# Altium schematic sheet P10_MISCELLANEOUS.SchDoc(P10_MISCELLANEOUS)
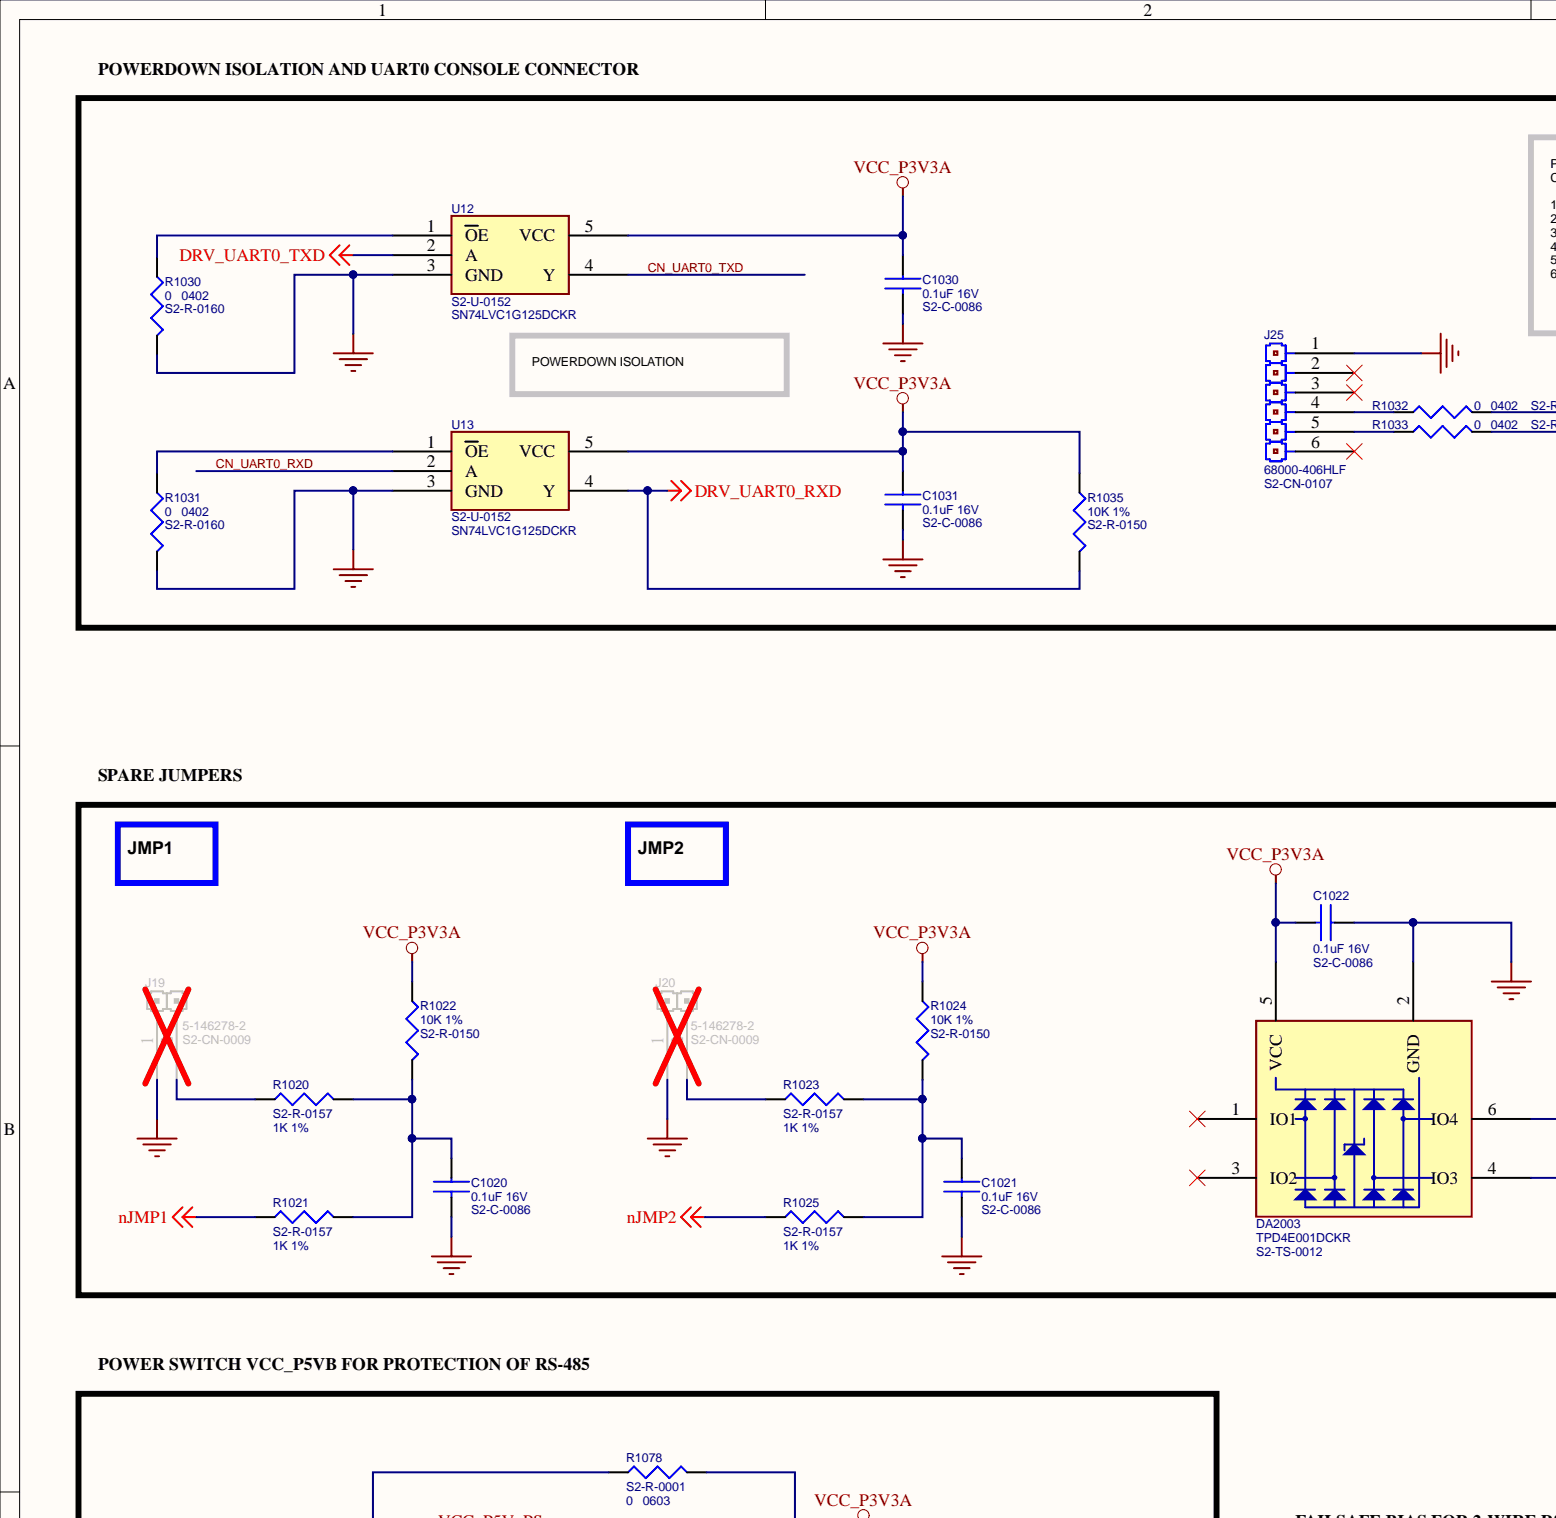
[diagram p0: P10_MISCELLANEOUS.SchDoc, top left]
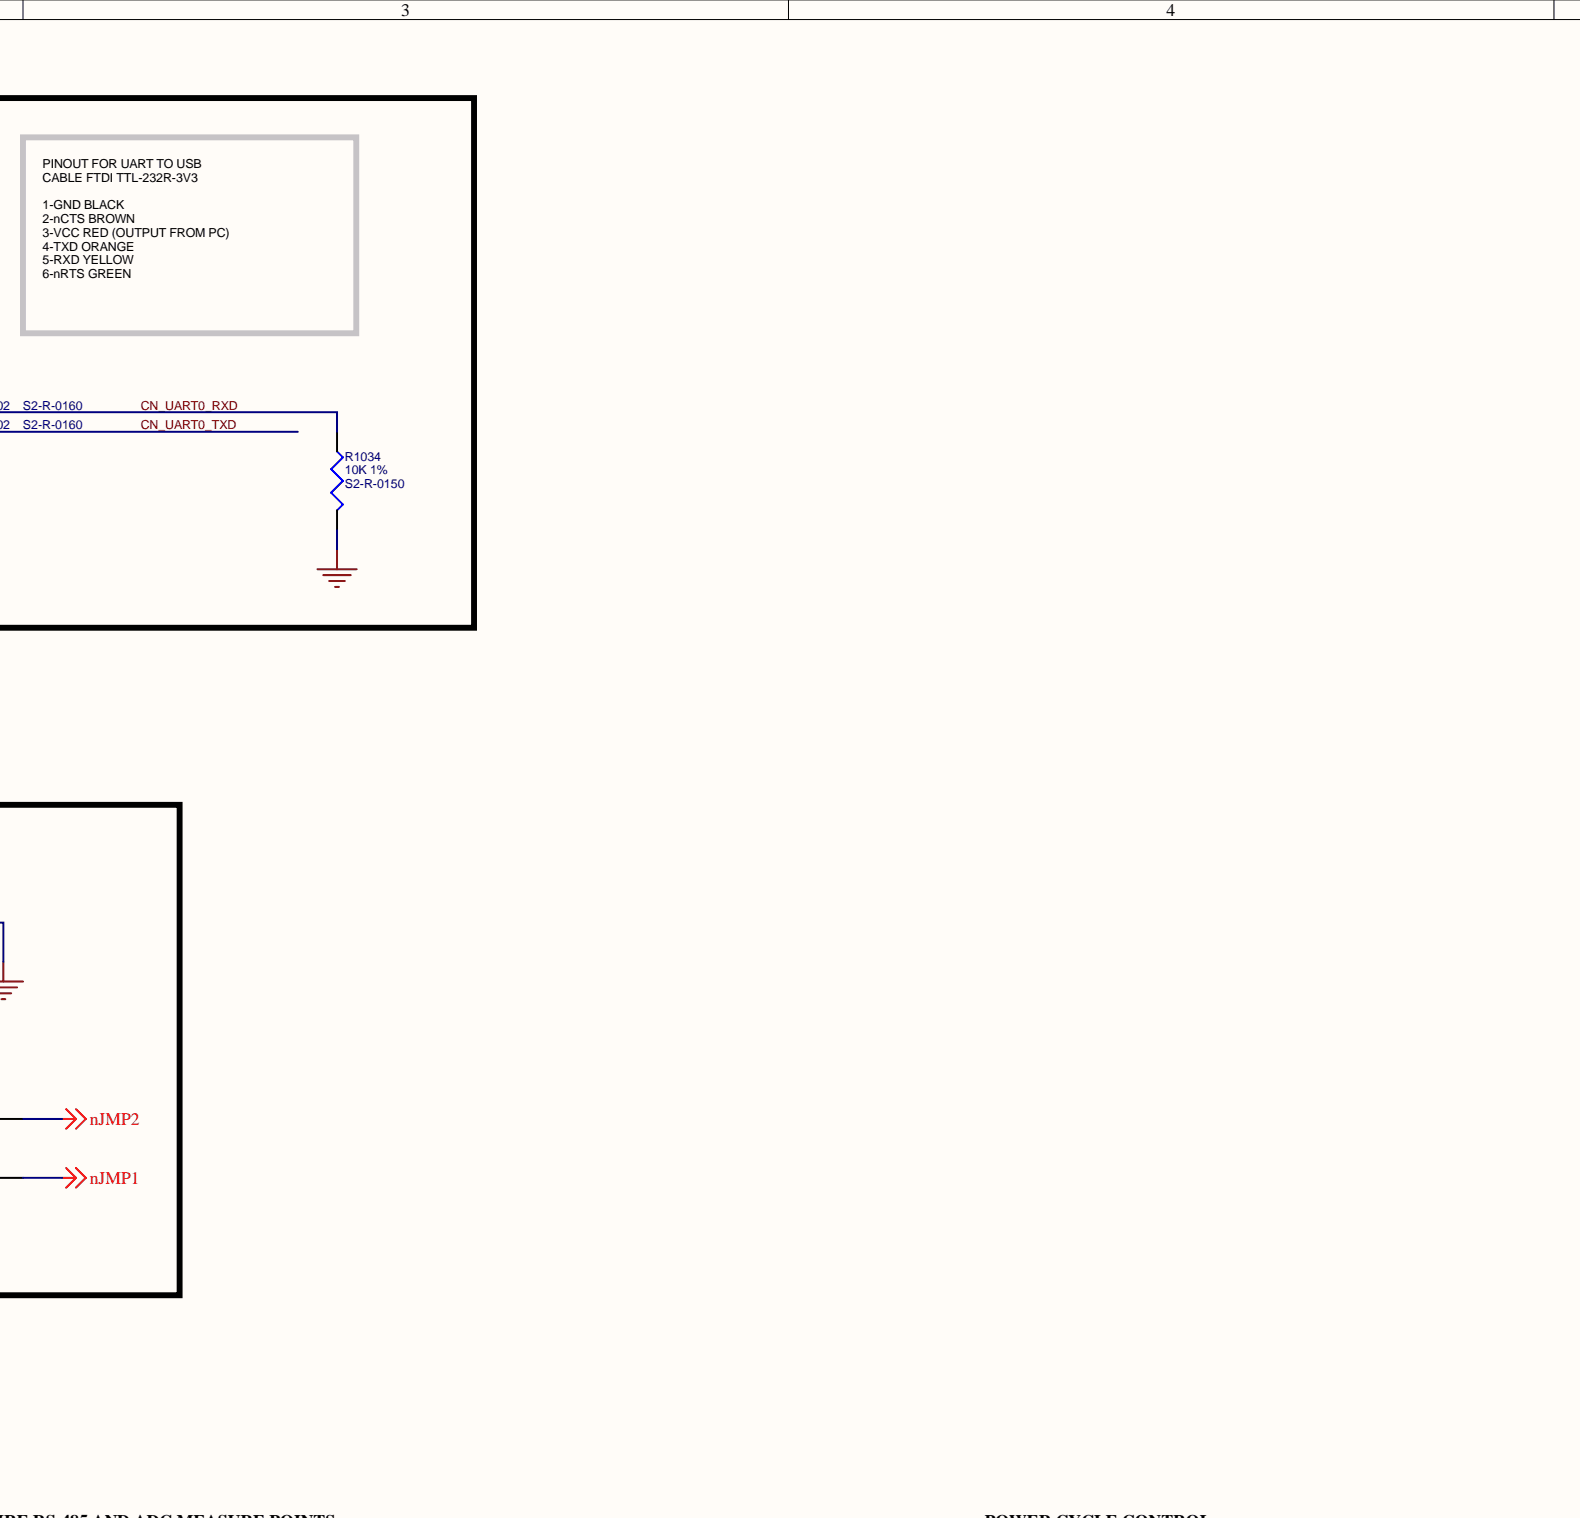
[diagram p1: P10_MISCELLANEOUS.SchDoc, top centre]
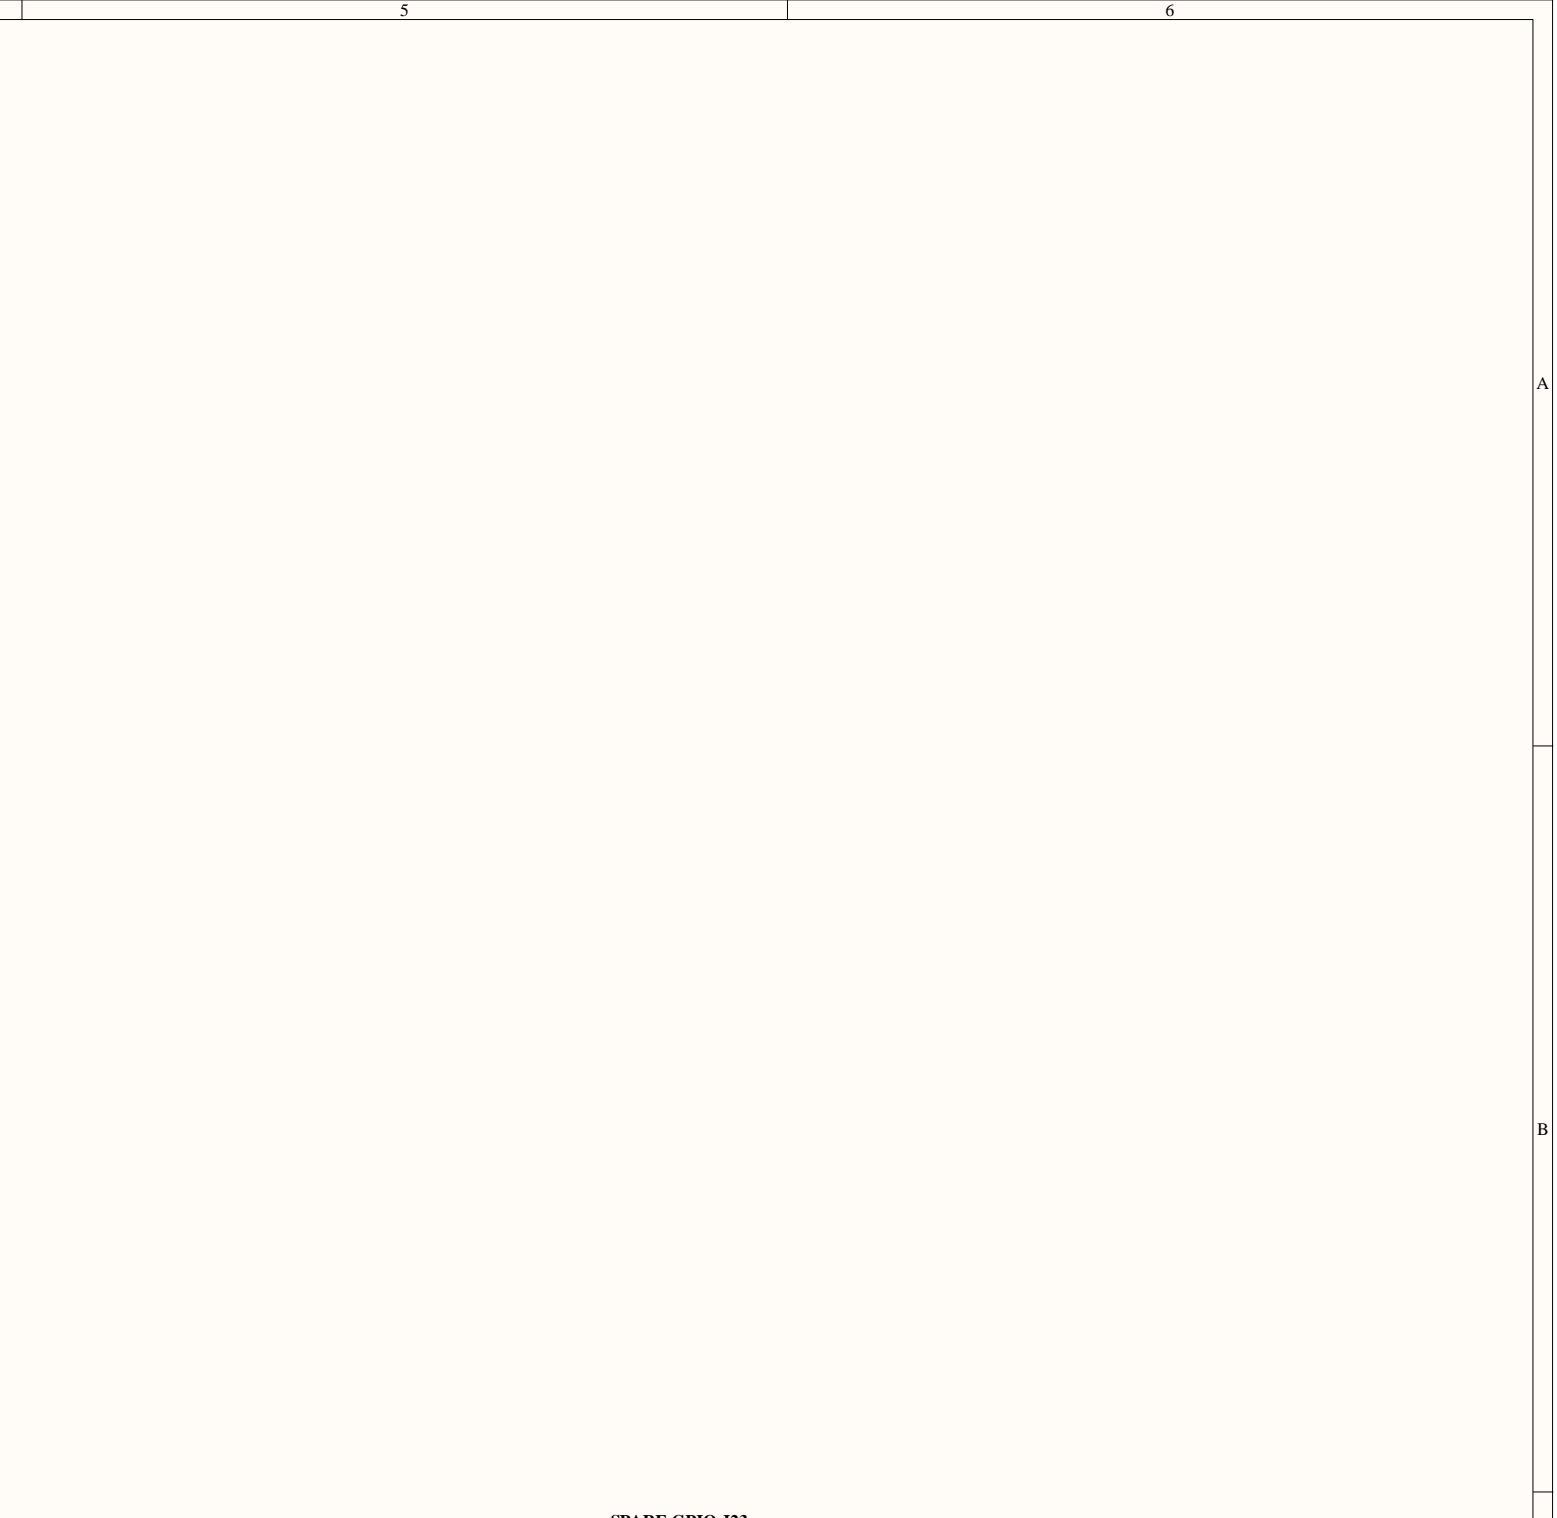
[diagram p2: P10_MISCELLANEOUS.SchDoc, top right]
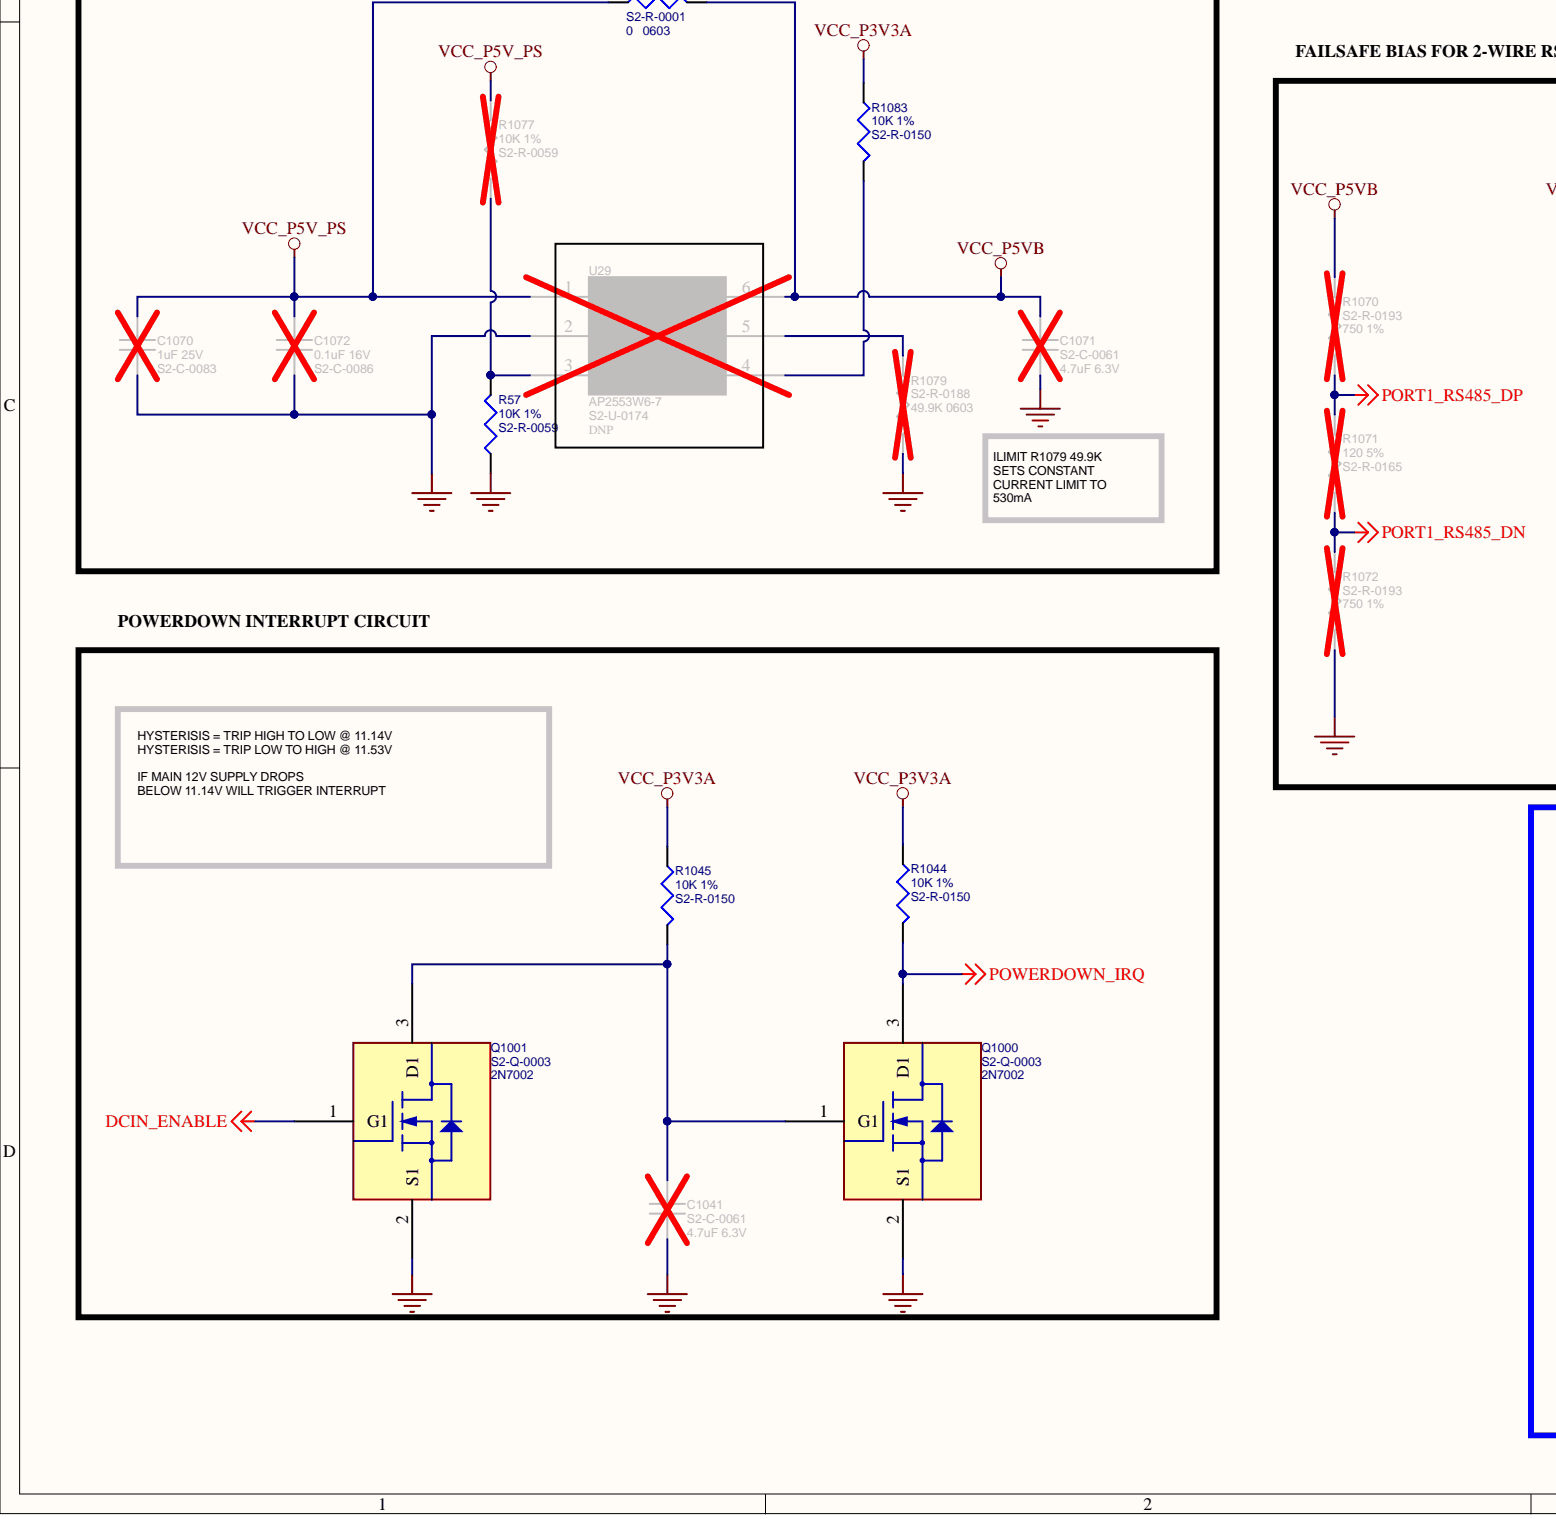
[diagram p3: P10_MISCELLANEOUS.SchDoc, bottom left]
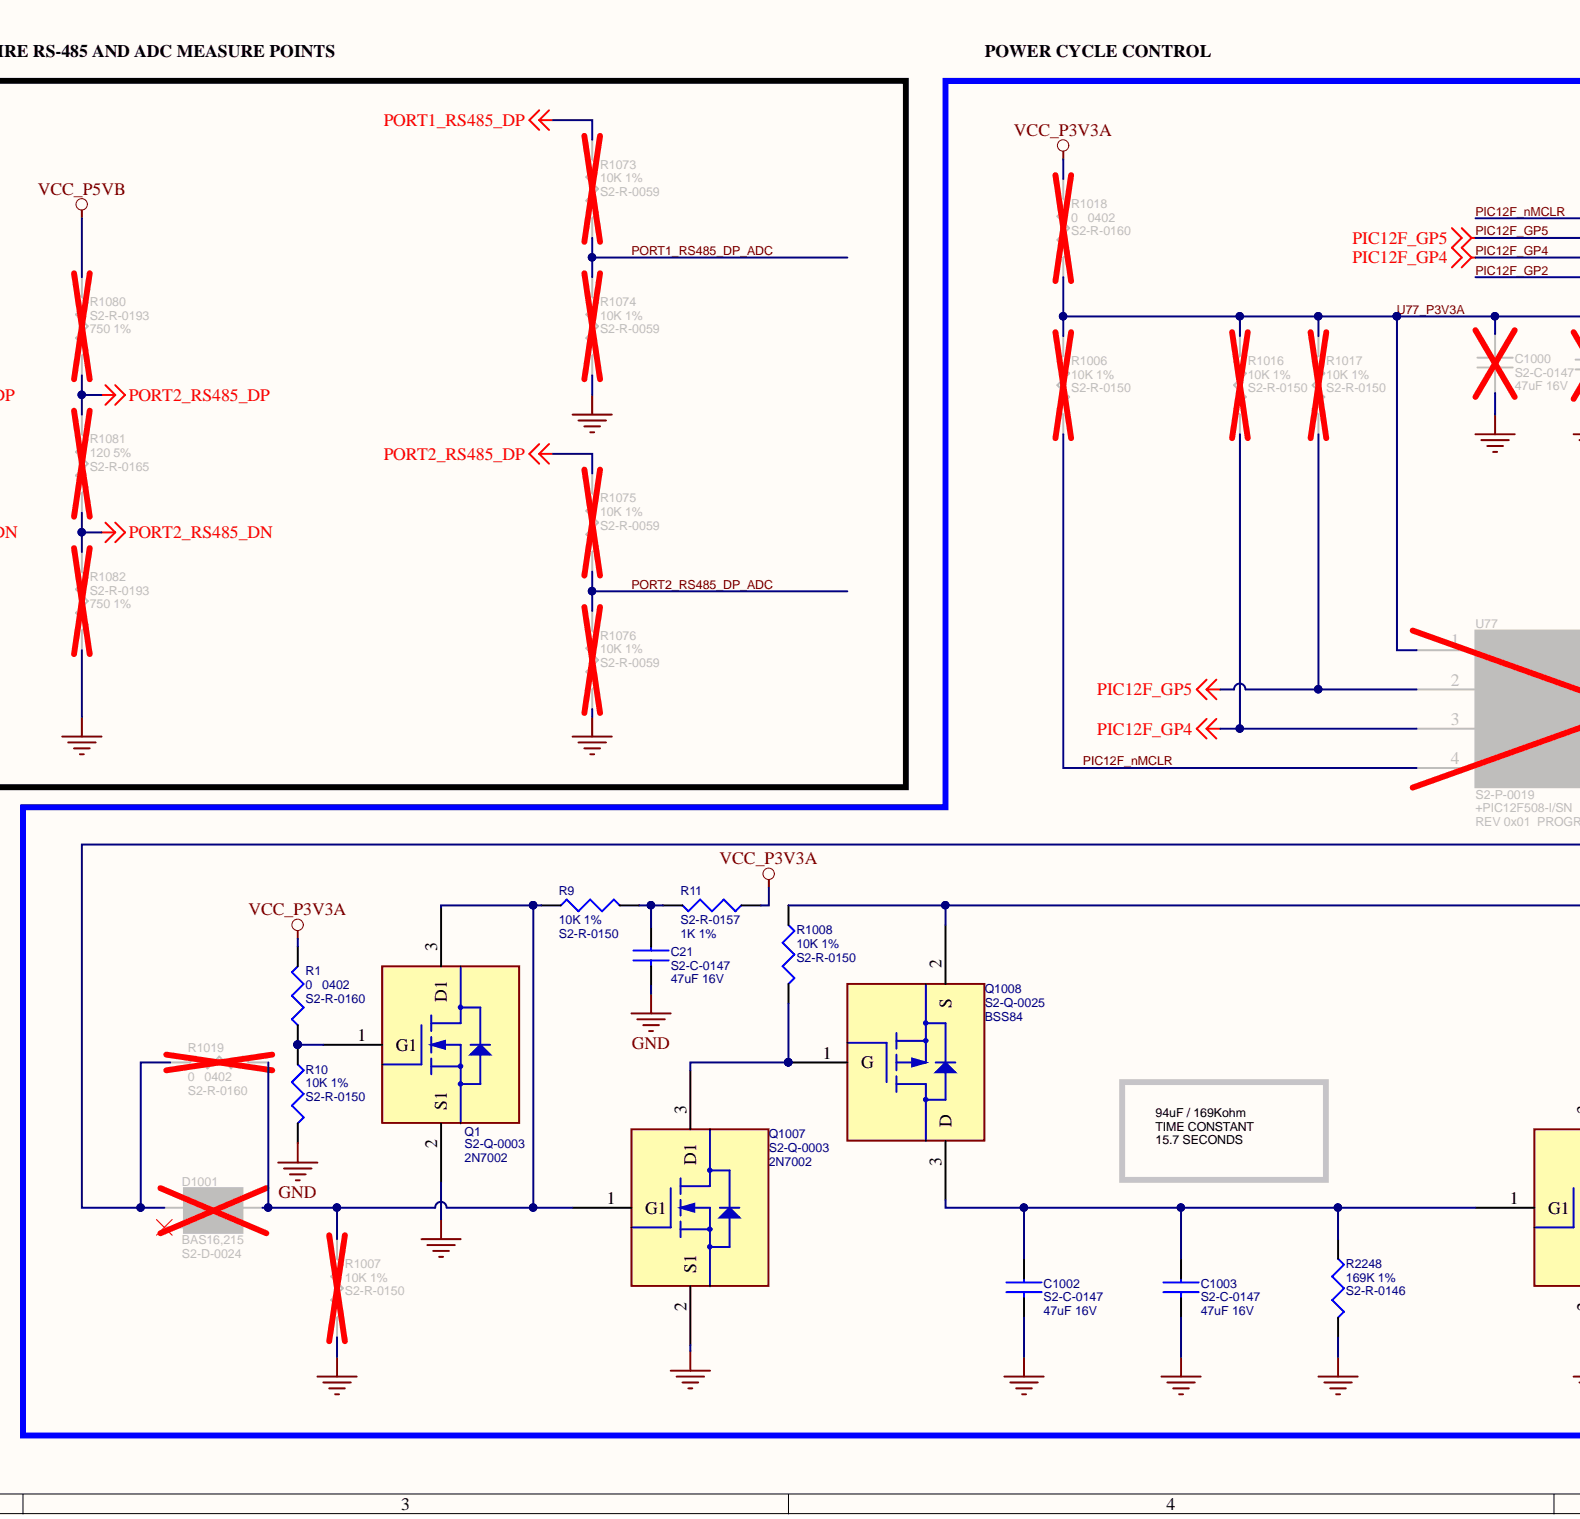
[diagram p4: P10_MISCELLANEOUS.SchDoc, bottom centre]
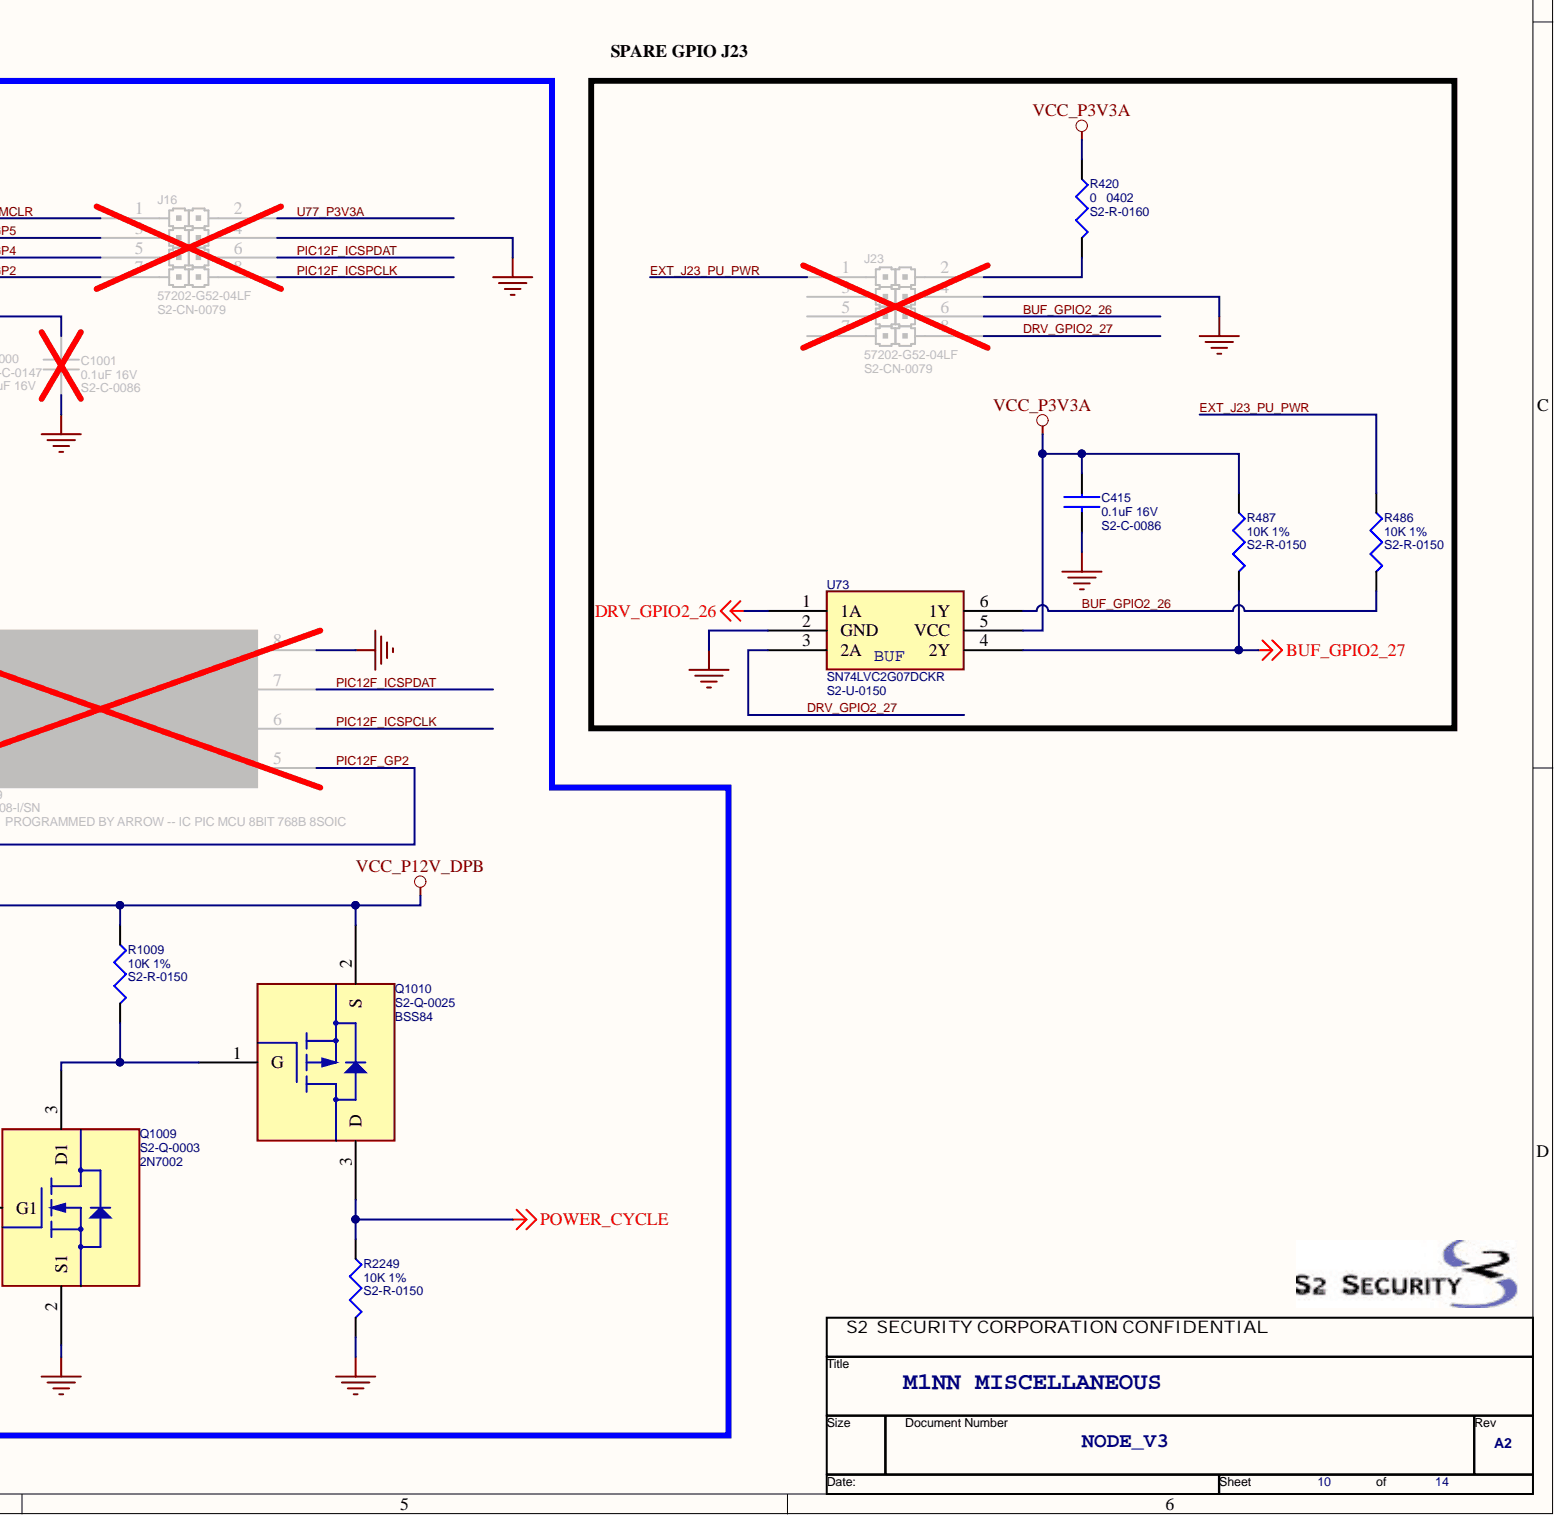
[diagram p5: P10_MISCELLANEOUS.SchDoc, bottom right]

## Parts
C21  S2_PN: S2-C-0147  DESC: 47uF 16V  [CAPC3216X180N]  (hidden comment: C_47U_16V_1206)
C415  S2_PN: S2-C-0086  DESC: 0.1uF 16V  [CAPC1005X55N]  (hidden comment: C_0U1_16V_0402)
C1000  S2_PN: S2-C-0147  [CAPC3216X180N]  (hidden comment: C_47U_16V_1206)
C1001  S2_PN: S2-C-0086  DESC: 0.1uF 16V  [CAPC1005X55N]  (hidden comment: C_0U1_16V_0402)
C1002  S2_PN: S2-C-0147  DESC: 47uF 16V  [CAPC3216X180N]  (hidden comment: C_47U_16V_1206)
C1003  S2_PN: S2-C-0147  DESC: 47uF 16V  [CAPC3216X180N]  (hidden comment: C_47U_16V_1206)
C1020  S2_PN: S2-C-0086  DESC: 0.1uF 16V  [CAPC1005X55N]  (hidden comment: C_0U1_16V_0402)
C1021  S2_PN: S2-C-0086  DESC: 0.1uF 16V  [CAPC1005X55N]  (hidden comment: C_0U1_16V_0402)
C1022  DESC: 0.1uF 16V  [CAPC1005X55N]  (hidden comment: C_0U1_16V_0402)
C1030  S2_PN: S2-C-0086  DESC: 0.1uF 16V  [CAPC1005X55N]  (hidden comment: C_0U1_16V_0402)
C1031  S2_PN: S2-C-0086  DESC: 0.1uF 16V  [CAPC1005X55N]  (hidden comment: C_0U1_16V_0402)
C1041  S2_PN: S2-C-0061  DESC: 4.7uF 6.3V  [CAPC1608X90N]  (hidden comment: C_4U7_6V3_0603)
C1070  S2_PN: S2-C-0083  DESC: 1uF 25V  [CAPC1608X90N]  (hidden comment: C_1U_25V_0603)
C1071  S2_PN: S2-C-0061  DESC: 4.7uF 6.3V  [CAPC1608X90N]  (hidden comment: C_4U7_6V3_0603)
C1072  S2_PN: S2-C-0086  DESC: 0.1uF 16V  [CAPC1005X55N]  (hidden comment: C_0U1_16V_0402)
D1001  S2_PN: S2-D-0024  DESC: BAS16,215  [SOT95P310X140-3N]  (hidden comment: D_BAS16_SOT23)
DA2003  S2_PN: S2-TS-0012  DESC: TPD4E001DCKR  [SOIC65Px210X110P-6N]  (hidden comment: DA_TPD4E001_ESD_QUAD)
J16  S2_PN: S2-CN-0079  PKG: 57202-G52-04LF  [CONN_57202-G52-04LF]  (hidden comment: CN_2MM_8POS_STR_DUAL_ROW_UNSHR)
J19  S2_PN: S2-CN-0009  PKG: 5-146278-2  [Conn_5-146278-2]  (hidden comment: CN_100_2POS_STR_UNSHR)
J20  S2_PN: S2-CN-0009  PKG: 5-146278-2  [Conn_5-146278-2]  (hidden comment: CN_100_2POS_STR_UNSHR)
J23  S2_PN: S2-CN-0079  PKG: 57202-G52-04LF  [CONN_57202-G52-04LF]  (hidden comment: CN_2MM_8POS_STR_DUAL_ROW_UNSHR)
J25  S2_PN: S2-CN-0107  DESC: 68000-406HLF  [CONN_68000-406HLF]  (hidden comment: CN_100_6POS_STR_UNSHR)
Q1  S2_PN: S2-Q-0003  DESC: 2N7002  [SOT95P310X140-3N]  (hidden comment: Q_NFET_2N7002)
Q1000  S2_PN: S2-Q-0003  DESC: 2N7002  [SOT95P310X140-3N]  (hidden comment: Q_NFET_2N7002)
Q1001  S2_PN: S2-Q-0003  DESC: 2N7002  [SOT95P310X140-3N]  (hidden comment: Q_NFET_2N7002)
Q1007  S2_PN: S2-Q-0003  DESC: 2N7002  [SOT95P310X140-3N]  (hidden comment: Q_NFET_2N7002)
Q1008  S2_PN: S2-Q-0025  DESC: BSS84  [SOT95P310X140-3N]  (hidden comment: Q_PFET_BSS84)
Q1009  S2_PN: S2-Q-0003  DESC: 2N7002  [SOT95P310X140-3N]  (hidden comment: Q_NFET_2N7002)
Q1010  S2_PN: S2-Q-0025  DESC: BSS84  [SOT95P310X140-3N]  (hidden comment: Q_PFET_BSS84)
R1  S2_PN: S2-R-0160  DESC: 0   0402  [RESC1005X40N]  (hidden comment: R_0_0402)
R9  S2_PN: S2-R-0150  DESC: 10K 1%  [RESC1005X40N]  (hidden comment: R_10K_0402)
R10  S2_PN: S2-R-0150  DESC: 10K 1%  [RESC1005X40N]  (hidden comment: R_10K_0402)
R11  S2_PN: S2-R-0157  [RESC1005X40N]  (hidden comment: R_1K_0402)
R57  S2_PN: S2-R-0059  DESC: 10K 1%  [RESC1608X55N]  (hidden comment: R_10K_0603)
R420  S2_PN: S2-R-0160  DESC: 0   0402  [RESC1005X40N]  (hidden comment: R_0_0402)
R486  S2_PN: S2-R-0150  DESC: 10K 1%  [RESC1005X40N]  (hidden comment: R_10K_0402)
R487  S2_PN: S2-R-0150  DESC: 10K 1%  [RESC1005X40N]  (hidden comment: R_10K_0402)
R1006  S2_PN: S2-R-0150  DESC: 10K 1%  [RESC1005X40N]  (hidden comment: R_10K_0402)
R1007  S2_PN: S2-R-0150  DESC: 10K 1%  [RESC1005X40N]  (hidden comment: R_10K_0402)
R1008  S2_PN: S2-R-0150  DESC: 10K 1%  [RESC1005X40N]  (hidden comment: R_10K_0402)
R1009  S2_PN: S2-R-0150  DESC: 10K 1%  [RESC1005X40N]  (hidden comment: R_10K_0402)
R1016  S2_PN: S2-R-0150  DESC: 10K 1%  [RESC1005X40N]  (hidden comment: R_10K_0402)
R1017  S2_PN: S2-R-0150  DESC: 10K 1%  [RESC1005X40N]  (hidden comment: R_10K_0402)
R1018  S2_PN: S2-R-0160  DESC: 0   0402  [RESC1005X40N]  (hidden comment: R_0_0402)
R1019  S2_PN: S2-R-0160  DESC: 0   0402  [RESC1005X40N]  (hidden comment: R_0_0402)
R1020  S2_PN: S2-R-0157  DESC: 1K 1%  [RESC1005X40N]  (hidden comment: R_1K_0402)
R1021  S2_PN: S2-R-0157  DESC: 1K 1%  [RESC1005X40N]  (hidden comment: R_1K_0402)
R1022  S2_PN: S2-R-0150  DESC: 10K 1%  [RESC1005X40N]  (hidden comment: R_10K_0402)
R1023  S2_PN: S2-R-0157  DESC: 1K 1%  [RESC1005X40N]  (hidden comment: R_1K_0402)
R1024  S2_PN: S2-R-0150  DESC: 10K 1%  [RESC1005X40N]  (hidden comment: R_10K_0402)
R1025  S2_PN: S2-R-0157  DESC: 1K 1%  [RESC1005X40N]  (hidden comment: R_1K_0402)
R1030  S2_PN: S2-R-0160  DESC: 0   0402  [RESC1005X40N]  (hidden comment: R_0_0402)
R1031  S2_PN: S2-R-0160  DESC: 0   0402  [RESC1005X40N]  (hidden comment: R_0_0402)
R1032  S2_PN: S2-R-0160  DESC: 0   0402  [RESC1005X40N]  (hidden comment: R_0_0402)
R1033  S2_PN: S2-R-0160  DESC: 0   0402  [RESC1005X40N]  (hidden comment: R_0_0402)
R1034  S2_PN: S2-R-0150  DESC: 10K 1%  [RESC1005X40N]  (hidden comment: R_10K_0402)
R1035  S2_PN: S2-R-0150  DESC: 10K 1%  [RESC1005X40N]  (hidden comment: R_10K_0402)
R1044  S2_PN: S2-R-0150  DESC: 10K 1%  [RESC1005X40N]  (hidden comment: R_10K_0402)
R1045  S2_PN: S2-R-0150  DESC: 10K 1%  [RESC1005X40N]  (hidden comment: R_10K_0402)
R1070  S2_PN: S2-R-0193  DESC: 750 1%  [RESC3225X70N]  (hidden comment: R_750_1210)
R1071  S2_PN: S2-R-0165  DESC: 120 5%  [RESC3225X70N]  (hidden comment: R_120_1210)
R1072  S2_PN: S2-R-0193  DESC: 750 1%  [RESC3225X70N]  (hidden comment: R_750_1210)
R1073  S2_PN: S2-R-0059  DESC: 10K 1%  [RESC1608X55N]  (hidden comment: R_10K_0603)
R1074  S2_PN: S2-R-0059  DESC: 10K 1%  [RESC1608X55N]  (hidden comment: R_10K_0603)
R1075  S2_PN: S2-R-0059  DESC: 10K 1%  [RESC1608X55N]  (hidden comment: R_10K_0603)
R1076  S2_PN: S2-R-0059  DESC: 10K 1%  [RESC1608X55N]  (hidden comment: R_10K_0603)
R1077  S2_PN: S2-R-0059  DESC: 10K 1%  [RESC1608X55N]  (hidden comment: R_10K_0603)
R1078  S2_PN: S2-R-0001  DESC: 0   0603  [RESC1608X55N]  (hidden comment: R_0_0603)
R1079  S2_PN: S2-R-0188  DESC: 49.9K 0603  [RESC1608X55N]  (hidden comment: R_49K9_0603)
R1080  S2_PN: S2-R-0193  DESC: 750 1%  [RESC3225X70N]  (hidden comment: R_750_1210)
R1081  S2_PN: S2-R-0165  DESC: 120 5%  [RESC3225X70N]  (hidden comment: R_120_1210)
R1082  S2_PN: S2-R-0193  DESC: 750 1%  [RESC3225X70N]  (hidden comment: R_750_1210)
R1083  S2_PN: S2-R-0150  DESC: 10K 1%  [RESC1005X40N]  (hidden comment: R_10K_0402)
R2248  S2_PN: S2-R-0146  DESC: 169K 1%  [RESC1608X55N]  (hidden comment: R_169K_0603)
R2249  S2_PN: S2-R-0150  DESC: 10K 1%  [RESC1005X40N]  (hidden comment: R_10K_0402)
U12  S2_PN: S2-U-0152  DESC: SN74LVC1G125DCKR  [SOT65x210x140x110-5N]  (hidden comment: U_SN74LVC1G125DCKR_BUFFER_W_TRI)
U13  S2_PN: S2-U-0152  DESC: SN74LVC1G125DCKR  [SOT65x210x140x110-5N]  (hidden comment: U_SN74LVC1G125DCKR_BUFFER_W_TRI)
U29  S2_PN: S2-U-0174  DESC: AP2553W6-7  [SOT95P280X145-6N]  (hidden comment: U_SWITCH_PWR_ILIMIT_AP2553W6-7)
U73  S2_PN: S2-U-0150  DESC: SN74LVC2G07DCKR  [SOIC65Px210X110P-6N]  (hidden comment: U_SN74LVC2G07DCKR_DUAL_BUFFER)
U77  S2_PN: S2-P-0019  DESC: +PIC12F508-I/SN  [SOIC127P600X175-8N]  (hidden comment: P_PIC12F508-I_SN_PROGRAMMMED)

## Nets
BUF_GPIO2_27: R487.2 U73.4(2Y)
DCIN_ENABLE: Q1001.1
DRV_GPIO2_26: U73.1(1A)
DRV_UART0_RXD: R1035.2 U13.4(Y)
DRV_UART0_TXD: U12.2(A)
GND: C21.2 C415.2 C1000.2 C1001.2 C1002.2 C1003.2 C1020.2 C1021.2 C1022.1 C1030.2 C1031.2 C1041.2 C1070.2 C1071.2 C1072.2 DA2003.2(GND) J16.4 J19.1 J20.1 J23.4 J25.1 Q1.2 Q1000.2 Q1001.2 Q1007.2 Q1009.2 R10.2 R57.2 R1007.2 R1030.2 R1031.2 R1034.2 R1072.2 R1074.2 R1076.2 R1079.2 R1082.2 R2248.2 R2249.2 U12.3(GND) U13.3(GND) U29.2(GND) U73.2(GND) U77.8(VSS)
nJMP1: DA2003.4(IO3) R1021.1
nJMP2: DA2003.6(IO4) R1025.1
PORT1_RS485_DN: R1071.2 R1072.1
PORT1_RS485_DP: R1070.2 R1071.1 R1073.1
PORT2_RS485_DN: R1081.2 R1082.1
PORT2_RS485_DP: R1075.1 R1080.2 R1081.1
POWER_CYCLE: Q1010.3 R2249.1
POWERDOWN_IRQ: Q1000.3 R1044.2
VCC_P3V3A: C415.1 C1022.2 C1030.1 C1031.1 DA2003.5(VCC) R1.2 R11.1 R420.1 R487.1 R1018.1 R1022.1 R1024.1 R1035.1 R1044.1 R1045.1 R1083.1 U12.5(VCC) U13.5(VCC) U73.5(VCC)
VCC_P5V_PS: C1070.1 C1072.1 R1077.1 R1078.1 U29.1(IN)
VCC_P5VB: C1071.1 R1070.1 R1078.2 R1080.1 U29.6(OUT)
VCC_P12V_DPB: Q1008.2 Q1010.2 R1008.1 R1009.1
BUF_GPIO2_26: J23.6 R486.2 U73.6(1Y)
CN_UART0_RXD: R1032.2 R1034.1 U13.2(A)
CN_UART0_TXD: R1033.2 U12.4(Y)
DRV_GPIO2_27: J23.8 U73.3(2A)
EXT_J23_PU_PWR: J23.1 R486.1
PIC12F_GP2: D1001.1 J16.7 R1019.1 U77.5(GP2/T0CKI)
PIC12F_GP4: J16.5 R1016.2 U77.3(GP4/OSC2)
PIC12F_GP5: J16.3 R1017.2 U77.2(GP5/OSC1/CLKIN)
PIC12F_ICSPCLK: J16.8 U77.6(GP1/ICSPCLK)
PIC12F_ICSPDAT: J16.6 U77.7(GP0/ICSPDAT)
PIC12F_nMCLR: J16.1 R1006.2 U77.4(GP3/MCLR/VPP)
PORT1_RS485_DP_ADC: R1073.2 R1074.1
PORT2_RS485_DP_ADC: R1075.2 R1076.1
U77_P3V3A: C1000.1 C1001.1 J16.2 R1006.1 R1016.1 R1017.1 R1018.2 U77.1(VDD)
NetC21_1: C21.1 R9.2 R11.2
NetC1002_1: C1002.1 C1003.1 Q1008.3 Q1009.1 R2248.1
NetC1020_1: C1020.1 R1020.2 R1021.2 R1022.2
NetC1021_1: C1021.1 R1023.2 R1024.2 R1025.2
NetC1041_1: C1041.1 Q1000.1 Q1001.3 R1045.2
NetD1001_3: D1001.3 Q1.3 Q1007.1 R9.1 R1007.1 R1019.2
NetJ19_2: J19.2 R1020.1
NetJ20_2: J20.2 R1023.1
NetJ23_2: J23.2 R420.2
NetJ25_4: J25.4 R1032.1
NetJ25_5: J25.5 R1033.1
NetQ1_1: Q1.1 R1.1 R10.1
NetQ1007_3: Q1007.3 Q1008.1 R1008.2
NetQ1009_3: Q1009.3 Q1010.1 R1009.2
NetR57_1: R57.1 R1077.2 U29.3(EN)
NetR1030_1: R1030.1 U12.1(OE)
NetR1031_1: R1031.1 U13.1(OE)
NetR1079_1: R1079.1 U29.5(ILIM)
NetR1083_2: R1083.2 U29.4(FAULT)

Not connected: D1001 2; DA2003 1(IO1) 3(IO2); J23 3 5 7; J25 2 3 6

## Library data of the parts
C21 C1002 C1003 = C_47U_16V_1206: PKG: CC1206IPC; ATTR: X5R 20%; Library Name: NODE_V2_M1.SCHLIB; Component Kind: Standard
C415 C1001 C1020 C1021 C1030 C1031 C1072 = C_0U1_16V_0402: PKG: CC0402IPC; ATTR: X7R 10%; Library Name: NODE_V2_M1.SCHLIB; Component Kind: Standard
C1000 = C_47U_16V_1206: PKG: CC1206IPC; DESC: 47uF 16V; ATTR: X5R 20%; Library Name: NODE_V2_M1.SCHLIB; Component Kind: Standard
C1022 = C_0U1_16V_0402: S2_PN: S2-C-0086; PKG: CC0402IPC; ATTR: X7R 10%; Library Name: NODE_V2_M1.SCHLIB; Component Kind: Standard
C1041 C1071 = C_4U7_6V3_0603: PKG: CC0603IPC; ATTR: X5R 10%; Library Name: NODE_V2_M1.SCHLIB; Component Kind: Standard
C1070 = C_1U_25V_0603: PKG: CC0603IPC; ATTR: X7R 10%; Library Name: NODE_V2_M1.SCHLIB; Component Kind: Standard
D1001 = D_BAS16_SOT23: PKG: SOT-23-3; ATTR: DIODE SWITCHING 500NA REVERSE LEAKAGE SOT-23-3; Library Name: NODE_V2_M1.SCHLIB; Component Kind: Standard
DA2003 = DA_TPD4E001_ESD_QUAD: PKG: SC-70-6; ATTR: ESD PROTECTION QUAD LOW VOLT LOW VAP; Library Name: NODE_V2_M1.SCHLIB; Component Kind: Standard
J16 J23 = CN_2MM_8POS_STR_DUAL_ROW_UNSHR: ATTR: HEADER MALE 8POS 2MM DUAL ROW UNSHROUDED; Library Name: NODE_V2_M1.SCHLIB; DESC: 57202-G52-04LF; Component Kind: Standard
J19 J20 = CN_100_2POS_STR_UNSHR: ATTR: HEADER MALE 2POS 0.100" STRAIGHT UNSHROUDED; Library Name: NODE_V2_M1.SCHLIB; DESC: 5-146278-2; Component Kind: Standard
J25 = CN_100_6POS_STR_UNSHR: PKG: 2MM54_6X1; ATTR: HEADER MALE UNSHROUDED 0.100" 6PIN 6X1 TH; Library Name: NODE_V2_M1.SCHLIB; Component Kind: Standard
Q1 Q1000 Q1001 Q1007 Q1009 = Q_NFET_2N7002: PKG: SOT-23; ATTR: MOSFET N-CHAN 60V 7.5OHM; Library Name: NODE_V2_M1.SCHLIB; Component Kind: Standard
Q1008 Q1010 = Q_PFET_BSS84: PKG: SOT-23; ATTR2: -50V 0.13A 10OHM; ATTR: MOSFET P-CH 10 OHM -50V 0.13A SOT-23; Library Name: NODE_V2_M1.SCHLIB; Component Kind: Standard
R1 R420 R1018 R1019 R1030-R1033 = R_0_0402: PKG: CC0402IPC; ATTR: 1/16W  50mohm MAX; Library Name: NODE_V2_M1.SCHLIB; Component Kind: Standard
R9 R10 R486 R487 R1006-R1009 R1016 R1017 R1022 R1024 R1034 R1035 R1044 R1045 R1083 R2249 = R_10K_0402: PKG: CC0402IPC; ATTR: 1/16W; Library Name: NODE_V2_M1.SCHLIB; Component Kind: Standard
R11 = R_1K_0402: PKG: CC0402IPC; DESC: 1K 1%; ATTR: 1/16W; Library Name: NODE_V2_M1.SCHLIB; Component Kind: Standard
R57 R1073-R1077 = R_10K_0603: PKG: CC0603IPC; ATTR: 1/10W; Library Name: NODE_V2_M1.SCHLIB; Component Kind: Standard
R1020 R1021 R1023 R1025 = R_1K_0402: PKG: CC0402IPC; ATTR: 1/16W; Library Name: NODE_V2_M1.SCHLIB; Component Kind: Standard
R1070 R1072 R1080 R1082 = R_750_1210: PKG: CC1210IPC; ATTR: 1/2W; Library Name: NODE_V2_M1.SCHLIB; Component Kind: Standard
R1071 R1081 = R_120_1210: PKG: CC1210IPC; ATTR: 1/2W; Library Name: NODE_V2_M1.SCHLIB; Component Kind: Standard
R1078 = R_0_0603: PKG: CC0603IPC; ATTR: 1/10W 50mohm MAX; Library Name: NODE_V2_M1.SCHLIB; Component Kind: Standard
R1079 = R_49K9_0603: PKG: CC0603IPC; ATTR: RES 49.9K OHM 1/10W 1% 0603; Library Name: NODE_V2_M1.SCHLIB; Component Kind: Standard
R2248 = R_169K_0603: PKG: CC0603IPC; ATTR: RES 169K OHM 1/10W 1% 0603; Library Name: NODE_V2_M1.SCHLIB; Component Kind: Standard
U12 U13 = U_SN74LVC1G125DCKR_BUFFER_W_TRI: PKG: SC70-5; ATTR: IC SINGLE BUFFER W/ 3-STATE OUTPUT 1.65V-5.5V; Library Name: NODE_V2_M1.SCHLIB; Component Kind: Standard
U29 = U_SWITCH_PWR_ILIMIT_AP2553W6-7: PKG: SOT-23-6; ATTR: IC SWITCH PWR CURR LIMIT SOT26; Library Name: NODE_V2_M1.SCHLIB; DNP: DNP; Component Kind: Standard
U73 = U_SN74LVC2G07DCKR_DUAL_BUFFER: PKG: SOT-363; ATTR: IC 74LVC2G07 BUFFER DUAL OPEN DRAIN 1.65V-5.5V OPERATION; Library Name: NODE_V2_M1.SCHLIB; Component Kind: Standard
U77 = P_PIC12F508-I_SN_PROGRAMMMED: PKG: SOIC-8; ATTR2: REV 0x01  PROGRAMMED BY ARROW -- IC PIC MCU 8BIT 768B 8SOIC; ATTR: PREPROGRAMMED BY ARROW  IC PIC MCU 8BIT 768B 8SOIC  S2-P-0018; Library Name: NODE_V2_M1.SCHLIB; Component Kind: Standard
